# Altium schematic sheet drvoutput1.SchDoc(drvoutput1)
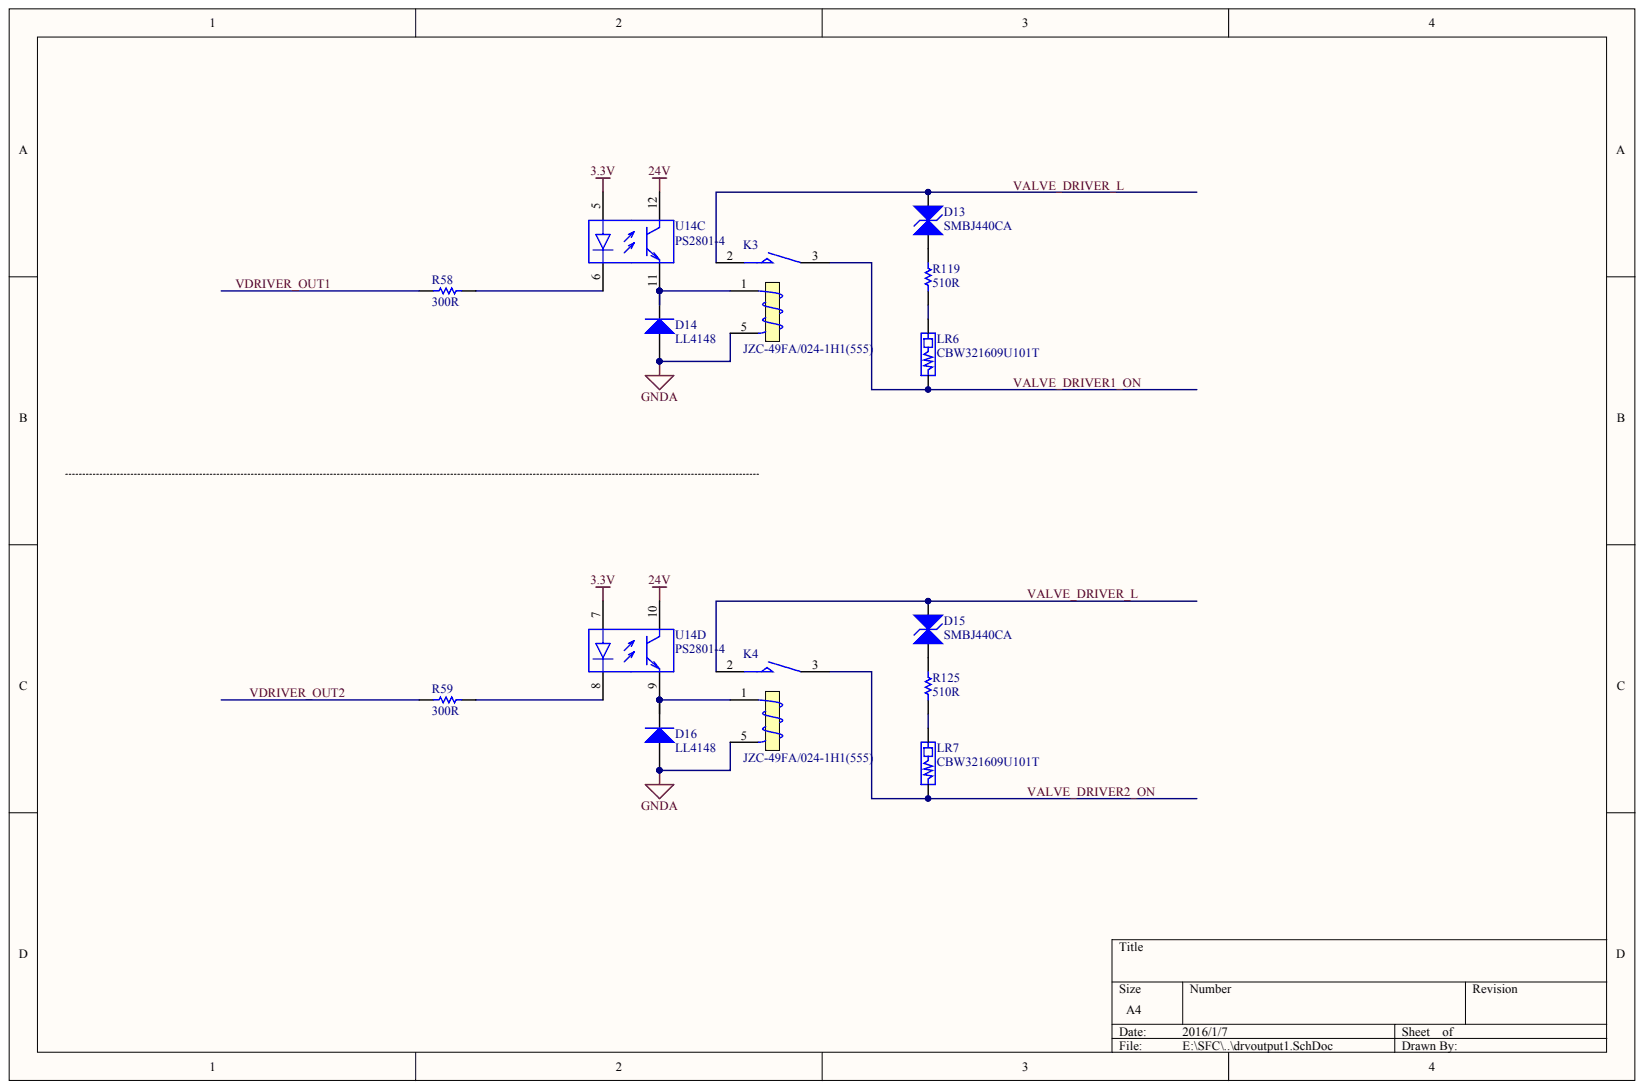
[diagram p0: drvoutput1.SchDoc]

## Parts
D13  SMBJ440CA
D14  LL4148
D15  SMBJ440CA
D16  LL4148
K3  JZC-49FA/024-1H1(555)
K4  JZC-49FA/024-1H1(555)
LR6  CBW321609U101T
LR7  CBW321609U101T
R58  300R
R59  300R
R119  510R
R125  510R
U14  PS2801-4  (parts C,D)

## Nets
3.3V: U14.5 U14.7
24V: U14.10 U14.12
GNDA: D14.1 D16.1 K3.5 K4.5
VALVE_DRIVER1_ON: K3.3 LR6.1
VALVE_DRIVER2_ON: K4.3 LR7.1
VALVE_DRIVER_L: D13.2 D15.2 K3.2 K4.2
VDRIVER_OUT1: R58.1
VDRIVER_OUT2: R59.1
NetD13_1: D13.1 R119.2
NetD14_2: D14.2 K3.1 U14.11
NetD15_1: D15.1 R125.2
NetD16_2: D16.2 K4.1 U14.9
NetLR6_2: LR6.2 R119.1
NetLR7_2: LR7.2 R125.1
NetR58_2: R58.2 U14.6
NetR59_2: R59.2 U14.8

## Library data of the parts
R58 R59 R119 R125 = (no symbol name): Value: 10K; Published: 8-Jun-2000; LatestRevisionDate: 17-Jul-2002; LatestRevisionNote: Re-released for DXP Platform.; PackageReference: AXIAL-0.3; Publisher: Altium Limited
D13-D16 K3 K4 LR6 LR7 U14 = (no symbol name)
  D13 D15: Published: 8-Jun-2000; LatestRevisionDate: 17-Jul-2002; LatestRevisionNote: Re-released for DXP Platform.; PackageReference: DIODE-0.7
  D14 D16: Published: 8-Jun-2000; LatestRevisionDate: 17-Jul-2002; LatestRevisionNote: Re-released for DXP Platform.; DatasheetVersion: 1998; PackageVersion: Aug-1999; PackageReference: DO-41; Code_JEDEC: DO-204-AL; ComponentLink1Description: Manufacturer Link; ComponentLink1URL: http://www.fairchildsemi.com/; ComponentLink2Description: Datasheet; ComponentLink2URL: http://www.fairchildsemi.com/ds/1N%2F1N4001.pdf
  K3 K4: Published: 8-Jun-2000; LatestRevisionDate: 17-Jul-2002; LatestRevisionNote: Re-released for DXP Platform.; PackageReference: MODULE5B
  U14: Published: 28-Feb-2003; LatestRevisionDate: 13-Apr-2006; LatestRevisionNote: IPC-7351 Footprint Added.; DatasheetVersion: Jan-2002, Rev. 7; PackageVersion: rev7, Jan-2002; PackageReference: 751-07; PackageDescription: 8-Pin Plastic Small Outline Integrated Circuit 1.27 mm Pitch; Code_JEDEC: MS-012-AA; Code_IPC: SOIC127P600-8; ComponentLink1Description: Manufacturer Link; ComponentLink1URL: http://www.onsemi.com/; ComponentLink2Description: Datasheet; ComponentLink2URL: http://www.onsemi.com/pub/Collateral/LM358-D.PDF
  D13-D16 K3 K4 U14: Publisher: Altium Limited
